# Altium schematic sheet QCW Bridge PCBA.SchDoc("QCW Bridge PCBA")
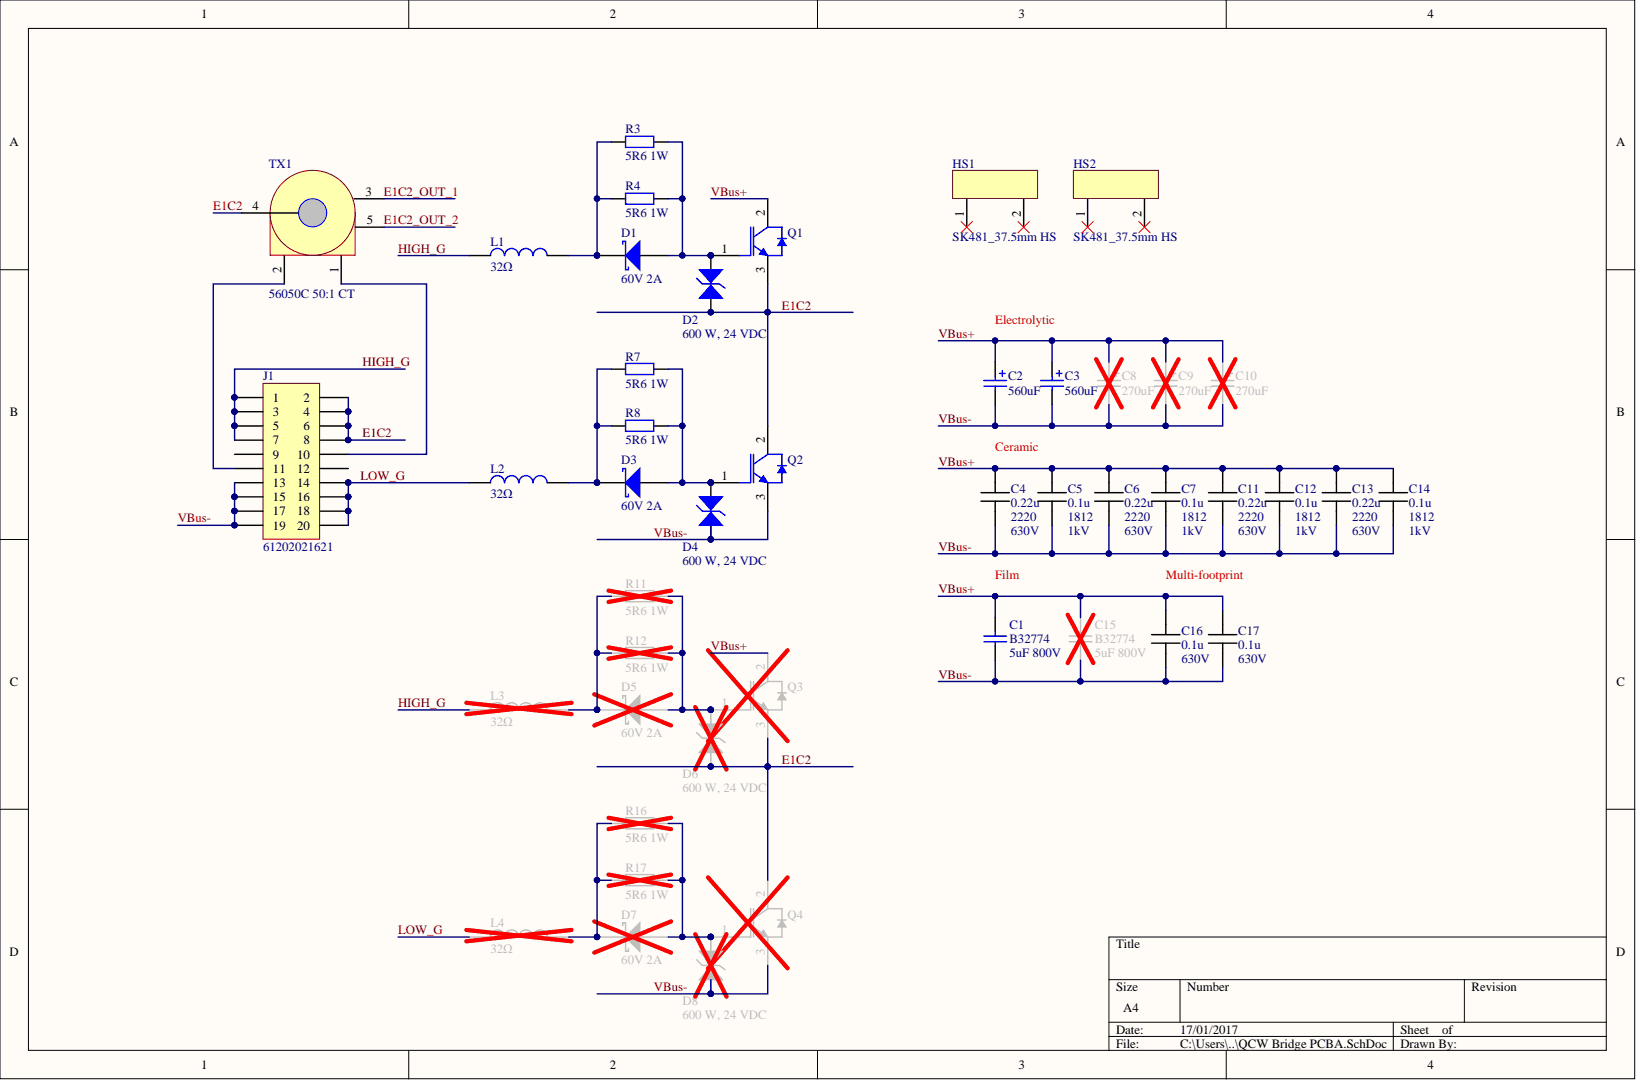
[diagram p0: QCW Bridge PCBA.SchDoc]

## Parts
C1  B32774  value 5uF 800V  [B32774 5uF 800V]
C2  560uF  [WCAP-AIL8_35x47]
C3  560uF  [WCAP-AIL8_35x47]
C4  voltage: 630V  package: 2220  Capacitance: 0.22u  [2220C]  (hidden comment: Capacitor)
C5  voltage: 1kV  package: 1812  Capacitance: 0.1u  [1812C]  (hidden comment: Capacitor)
C6  voltage: 630V  package: 2220  Capacitance: 0.22u  [2220C]  (hidden comment: Capacitor)
C7  voltage: 1kV  package: 1812  Capacitance: 0.1u  [1812C]  (hidden comment: Capacitor)
C8  270uF  [WCAP-AIL8_25x46]
C9  270uF  [WCAP-AIL8_25x46]
C10  270uF  [WCAP-AIL8_25x46]
C11  voltage: 630V  package: 2220  Capacitance: 0.22u  [2220C]  (hidden comment: Capacitor)
C12  voltage: 1kV  package: 1812  Capacitance: 0.1u  [1812C]  (hidden comment: Capacitor)
C13  voltage: 630V  package: 2220  Capacitance: 0.22u  [2220C]  (hidden comment: Capacitor)
C14  voltage: 1kV  package: 1812  Capacitance: 0.1u  [1812C]  (hidden comment: Capacitor)
C15  B32774  value 5uF 800V  [B32774 5uF 800V]
C16  voltage: 630V  Capacitance: 0.1u  [Cap_multi_footprint]  (hidden comment: Capacitor)
C17  voltage: 630V  Capacitance: 0.1u  [Cap_multi_footprint]  (hidden comment: Capacitor)
D1  60V 2A  [DO-214AA_(SMB-J-BEND)_UNI]
D2  600 W, 24 VDC  [DO-214AA_Bidirectional]
D3  60V 2A  [DO-214AA_(SMB-J-BEND)_UNI]
D4  600 W, 24 VDC  [DO-214AA_Bidirectional]
D5  60V 2A  [DO-214AA_(SMB-J-BEND)_UNI]
D6  600 W, 24 VDC  [DO-214AA_Bidirectional]
D7  60V 2A  [DO-214AA_(SMB-J-BEND)_UNI]
D8  600 W, 24 VDC  [DO-214AA_Bidirectional]
HS1  SK481_37.5mm HS  [SK 481 37.5]
HS2  SK481_37.5mm HS  [SK 481 37.5_FLIP]
J1  61202021621  [61202021621]
L1  32Ω  [WE-CBF_1206_High Speed]
L2  32Ω  [WE-CBF_1206_High Speed]
L3  32Ω  [WE-CBF_1206_High Speed]
L4  32Ω  [WE-CBF_1206_High Speed]
Q1  (no value)  [TO-247]
Q2  (no value)  [TO-247]
Q3  (no value)  [TO-247]
Q4  (no value)  [TO-247]
R3  value 5R6 1W  [RES_2512]  (hidden comment: Res2)
R4  value 5R6 1W  [RES_2512]  (hidden comment: Res2)
R7  value 5R6 1W  [RES_2512]  (hidden comment: Res2)
R8  value 5R6 1W  [RES_2512]  (hidden comment: Res2)
R11  value 5R6 1W  [RES_2512]  (hidden comment: Res2)
R12  value 5R6 1W  [RES_2512]  (hidden comment: Res2)
R16  value 5R6 1W  [RES_2512]  (hidden comment: Res2)
R17  value 5R6 1W  [RES_2512]  (hidden comment: Res2)
TX1  56050C 50:1 CT  [KMP_5600_ALT]

## Nets
E1C2: D2.2 D6.2 J1.2 J1.4 J1.6 J1.8 Q1.3 Q2.2 Q3.3 Q4.2 TX1.4
E1C2_OUT_1: TX1.3
E1C2_OUT_2: TX1.5
HIGH_G: J1.1 J1.3 J1.5 J1.7 L1.1 L3.1
LOW_G: J1.14 J1.16 J1.18 J1.20 L2.1 L4.1
VBus+: C1.1 C2.1 C3.1 C4.1 C5.1 C6.1 C7.1 C8.1 C9.1 C10.1 C11.1 C12.1 C13.1 C14.1 C15.1 C16.1 C17.2 Q1.2 Q3.2
VBus-: C1.2 C2.2 C3.2 C4.2 C5.2 C6.2 C7.2 C8.2 C9.2 C10.2 C11.2 C12.2 C13.2 C14.2 C15.2 C16.2 C17.1 D4.2 D8.2 J1.13 J1.15 J1.17 J1.19 Q2.3 Q4.3
NetD1_A: D1.A D2.1 Q1.1 R3.2 R4.2
NetD1_K: D1.K L1.2 R3.1 R4.1
NetD3_A: D3.A D4.1 Q2.1 R7.2 R8.2
NetD3_K: D3.K L2.2 R7.1 R8.1
NetD5_A: D5.A D6.1 Q3.1 R11.2 R12.2
NetD5_K: D5.K L3.2 R11.1 R12.1
NetD7_A: D7.A D8.1 Q4.1 R16.2 R17.2
NetD7_K: D7.K L4.2 R16.1 R17.1
NetJ1_10: J1.10 TX1.1
NetJ1_11: J1.11 TX1.2

Not connected: HS1 1 2; HS2 1 2; J1 9 12

## Library data of the parts
C1 = Cap: Capacitor; Value: 5uF 800V; Simulation: CAP; Signal Integrity: Cap; Published: 8-Jun-2000; PackageReference: RAD-0.3; PackageDescription: Radial Cap, Thru-Hole; 2 Leads; 0.3 in Pin Spacing; Library Name: Miscellaneous Devices.IntLib; LatestRevisionNote: Re-released for DXP Platform.; LatestRevisionDate: 17-Jul-2002; Component Kind: Standard; Publisher: Altium Limited
C2 C3 = WCAP-AIL8, 861101486027: WCAP-AIL8 Aluminum Electrolytic Capacitors, 560uF; RatedVoltage: 450V; Value: 560uF; Size: 35mmx47mm; PublishedDate: July 2016; PartNumber: 861101486027; ComponentLink2URL: http://katalog.we-online.com/pbs/datasheet/861101486027.pdf; ComponentLink2Description: Datasheet; ComponentLink1URL: http://www.we-online.de; ComponentLink1Description: Manufacturer; Component Kind: Standard; Publisher: Wurth Elektronik; Library Name: TV_Altium_library.SchDoc
C8-C10 = WCAP-AIL8, 861101484015: WCAP-AIL8 Aluminum Electrolytic Capacitors, 270uF; RatedVoltage: 450V; Value: 270uF; Size: 25mmx46mm; PublishedDate: July 2016; PartNumber: 861101484015; ComponentLink2URL: http://katalog.we-online.com/pbs/datasheet/861101484015.pdf; ComponentLink2Description: Datasheet; ComponentLink1URL: http://www.we-online.de; ComponentLink1Description: Manufacturer; Component Kind: Standard; Publisher: Wurth Elektronik; Library Name: TV_Altium_library.SchLib
C15 = Cap: Capacitor; Simulation: CAP; Signal Integrity: Cap; Published: 8-Jun-2000; PackageReference: RAD-0.3; PackageDescription: Radial Cap, Thru-Hole; 2 Leads; 0.3 in Pin Spacing; Library Name: Miscellaneous Devices.IntLib; LatestRevisionNote: Re-released for DXP Platform.; LatestRevisionDate: 17-Jul-2002; Component Kind: Standard; Publisher: Altium Limited
D1 D3 D5 D7 = SS26: SS26 Schottky rectifier; Component Kind: Standard; Library Name: TV_Altium_library.SchDoc
D2 D4 D6 D8 = WE-TVSP DO-214AA, 824521241: WE-TVSP Power TVS Diode, bidirectional, 600 W, 24 VDC; Manufacturer: Wurth Elektronik; partNo: 824521241; Created Date: Nov.2015; ComponentLink2URL: http://katalog.we-online.de/pbs/datasheet/824521241.pdf; ComponentLink2Description: Datasheet; ComponentLink1URL: http://www.we-online.de; ComponentLink1Description: Manufacturer; Component Kind: Standard; Library Name: TV_Altium_library.SchDoc; Created by: Baojun Chen
HS1 HS2 = SK_481_37.5: Component Kind: Standard; Library Name: TV_Altium_library.SchDoc
J1 = 61202021621: WR-BHD 2.54mm Male Box Header; Manufacturer: Wurth Elektronik; NoPins: 20; ComponentLink2 Description: Datasheet; ComponentLink1 Description: Manufacturer; partNo: 61202021621; Created Date: March 2016; ComponentLink2URL: http://katalog.we-online.de/em/datasheet/6120xx21621.pdf; ComponentLink1URL: http://www.we-online.com; Component Kind: Standard; Library Name: TV_Altium_library.IntLib; Created by: Baojun Chen
L1-L4 = WE-CBF, 7427921: WE-CBF SMD EMI Suppression Ferrite Bead, 1206, 32Ω; Type: High Speed; Value: 32Ω; Size: 1206; PublishedDate: Aug 2016; PartNumber: 7427921; ComponentLink2URL: http://katalog.we-online.de/pbs/datasheet/7427921.pdf; ComponentLink2Description: Datasheet; ComponentLink1URL: http://www.we-online.de; ComponentLink1Description: Manufacturer; Component Kind: Standard; Publisher: Wurth Elektronik; Library Name: TV_Altium_library.SchDoc
Q1-Q4 = TO-247_IGBT_V: IGBT, TO-247, Vertical; Component Kind: Standard; Library Name: TV_Altium_library.SchDoc
R3 R4 R7 R8 R11 R12 R16 R17 = Res2: Resistor; Simulation: RESISTOR; Signal Integrity: Res; Published: 8-Jun-2000; PackageReference: AXIAL-0.4; PackageDescription: Axial Device, Thru-Hole; 2 Leads; 0.4 in Pin Spacing; Library Name: Miscellaneous Devices.IntLib; LatestRevisionNote: Re-released for DXP Platform.; LatestRevisionDate: 17-Jul-2002; Component Kind: Standard; Publisher: Altium Limited
TX1 = 56050C_ALT: Murata 56050C 10A 50:1 CT; Component Kind: Standard; Library Name: TV_Altium_library.SchLib
C4-C7 C11-C14 C16 C17 = Capacitor: Component Kind: Standard; Library Name: TV_Altium_library.SchLib
